# Altium schematic sheet SheetMSP.SchDoc(SheetMSP)
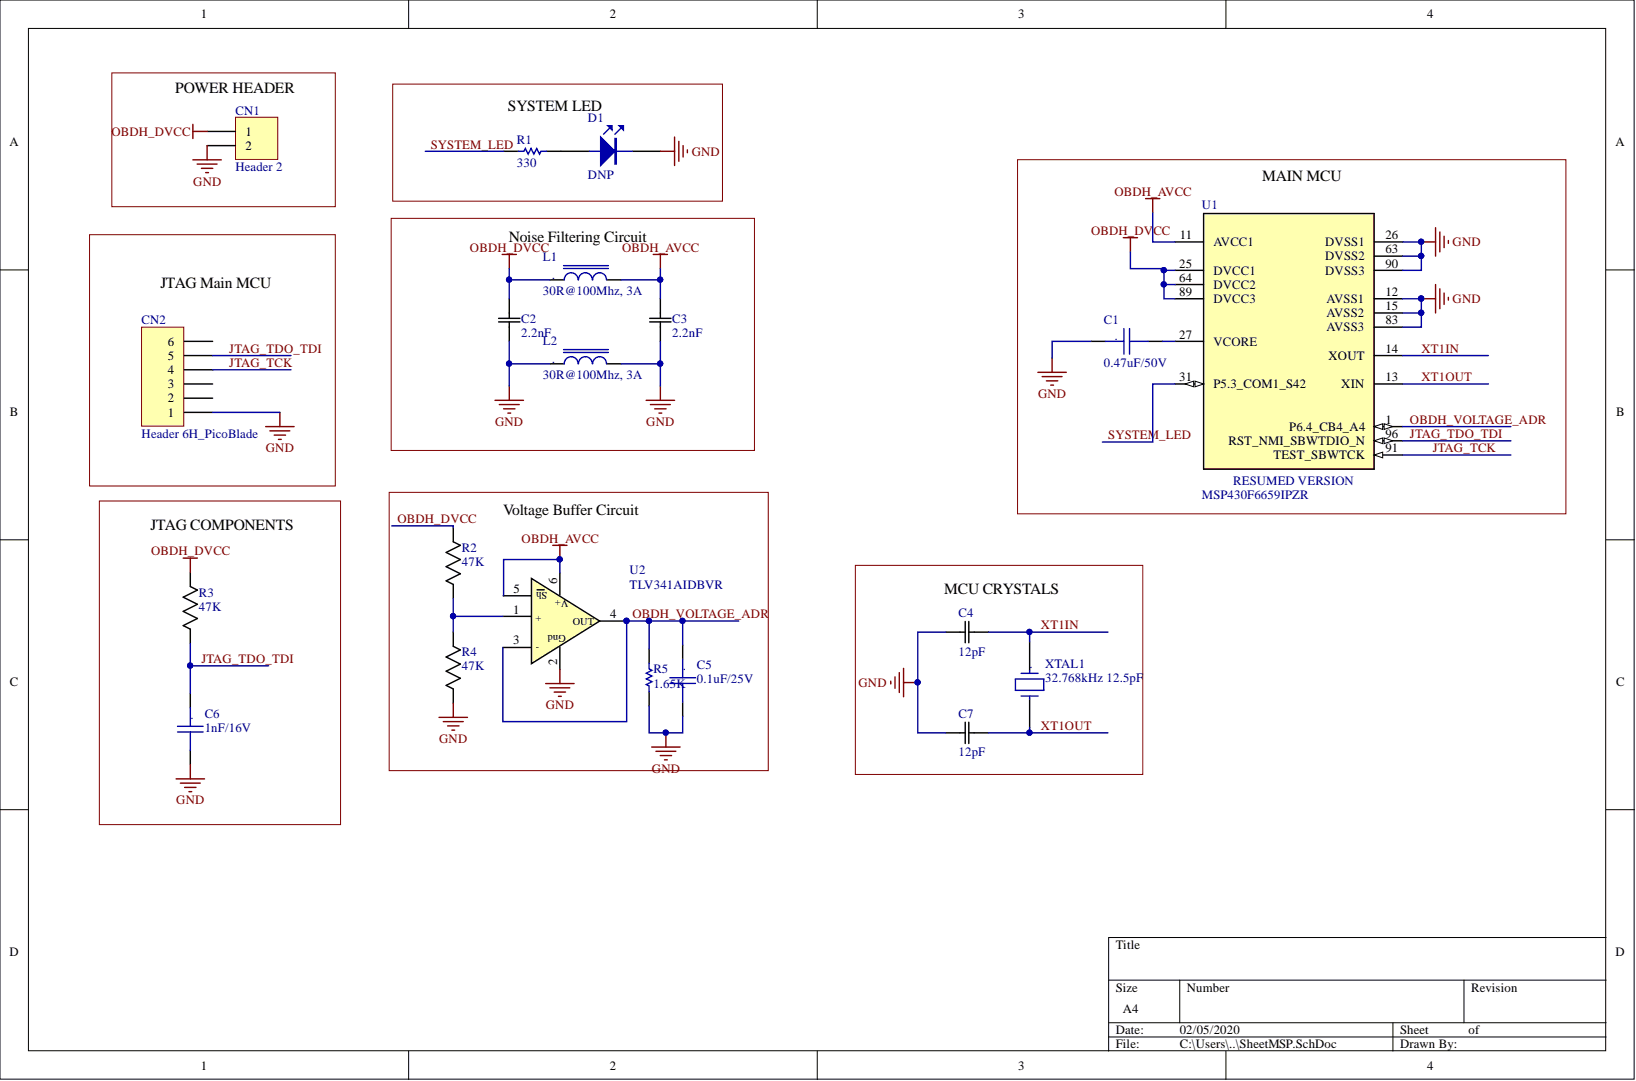
[diagram p0: SheetMSP.SchDoc]

## Parts
C1  0.47uF/50V  [C0805_MD]
C2  2.2nF  [C0402_MD]
C3  2.2nF  [C0402_MD]
C4  12pF  [GRM1555C1H120JA01J]
C5  0.1uF/25V  [C0805_MD]
C6  1nF/16V  [C0603_MD]
C7  12pF  [GRM1555C1H120JA01J]
CN1  Header 2  [HEADER 2M 2.54mm 180 GRAUS]
CN2  Header 6H_PicoBlade  [PICO BLADE 0532610671]
D1  DNP  [L0805 GREEN]
L1  30R@100Mhz, 3A  [L0805]
L2  30R@100Mhz, 3A  [L0805]
R1  330  [R0603_MD]
R2  47K  [R0805_MD]
R3  47K  [R0805_MD]
R4  47K  [R0805_MD]
R5  1.65K  [R0805_MD]
U1  MSP430F6659IPZR  [PZ0100A_N]
U2  TLV341AIDBVR  [SOT-23 6L]
XTAL1  32.768kHz 12.5pF  [ABS06 0805]

## Nets
GND: C1.1 C2.1 C3.1 C4.1 C5.2 C6.2 C7.1 CN1.2 CN2.1 D1.K L2.1 L2.2 R4.2 R5.1 U1.12(AVSS1) U1.15(AVSS2) U1.26(DVSS1) U1.63(DVSS2) U1.83(AVSS3) U1.90(DVSS3) U2.2
OBDH_AVCC: C3.2 L1.2 U1.11(AVCC1) U2.5(Sh) U2.6(V+)
JTAG_TCK: CN2.4 U1.91(TEST_SBWTCK)
JTAG_TDO_TDI: C6.1 CN2.5 R3.2 U1.96(RST_NMI_SBWTDIO_N)
OBDH_DVCC: C2.2 CN1.1 L1.1 R2.1 R3.1 U1.25(DVCC1) U1.64(DVCC2) U1.89(DVCC3)
OBDH_VOLTAGE_ADR: C5.1 R5.2 U1.1(P6.4_CB4_A4) U2.3(-) U2.4(OUT)
SYSTEM_LED: R1.2 U1.31(P5.3_COM1_S42)
XT1IN: C4.2 U1.14(XOUT) XTAL1.1
XT1OUT: C7.2 U1.13(XIN) XTAL1.2
NetC1_2: C1.2 U1.27(VCORE)
NetD1_A: D1.A R1.1
NetR2_2: R2.2 R4.1 U2.1(+)

Not connected: CN2 2 3 6

## Library data of the parts
C1 = Cap_Cer_X7R_0.47uF_50V_0805: CAP CER 0.47UF 50V 10% X7R 0805; Partnumber: C0805C474K5RACTU; NCM: 8532.24.10; Component Kind: Standard; Library Name: MPS430_Task.SchLib
C2 C3 = Cap 2.2nF/16V_0402: Imported; Manufacturer Part Number: GRM155R71C222KA01D; Voltage Rating (DC): 16V; Vendor: Murata; Type: DEV; Tolerance: 10%; Dielectric: X7R; C: Copyright (C) 2015 Accelerated Designs. All rights reserved; Built_By: EMA_UL_Team; Width: 0.02inch; Voltage Rating: 16V; Value: Value; Thickness: 0.022inch; Termination: SMD/SMT; Supplier Part Number 1: 490-6330-1-ND; Supplier 1: Digi-Key; Stock 1: 125973; RefDes: RefDes; Radiation Hardening: No; Pricing 1: 1=0.1, 10=0.03, 100=0.0134, 500=0.0096, 1000=0.0075, 2500=0.0068, 5000=0.0063 (USD); Packaging: Tape and Reel; Package Quantity: 10000; Mount: Surface Mount; Min Operating Temperature: -55°C; Max Operating Temperature: 125°C; Manufacturer_Part_Number: GRM155R71C222KA01D; Manufacturer: Murata; Length: 1mm; Lead Free: Lead Free; Height: 0.5mm; Depth: 0.5mm; ComponentLink6URL: https://datasheet.ciiva.com/6263/partnumbering-e-01-6263630.pdf; ComponentLink6Description: https://datasheet.ciiva.com/6263/partnumbering-e-01-6263630.pdf; ComponentLink5URL: https://datasheet.ciiva.com/11620/product-11620860.pdf; ComponentLink5Description: https://datasheet.ciiva.com/11620/product-11620860.pdf; ComponentLink4URL: https://datasheet.ciiva.com/17453/product-17453067.pdf; ComponentLink4Description: https://datasheet.ciiva.com/17453/product-17453067.pdf; ComponentLink3URL: https://datasheet.ciiva.com/6263/partnumbering-e-01-6263627.pdf; ComponentLink3Description: https://datasheet.ciiva.com/6263/partnumbering-e-01-6263627.pdf; ComponentLink2URL: https://datasheet.ciiva.com/6263/partnumbering-e-01-6263624.pdf; ComponentLink2Description: https://datasheet.ciiva.com/6263/partnumbering-e-01-6263624.pdf; ComponentLink1URL: https://datasheet.ciiva.com/5412/product-5412216.pdf; ComponentLink1Description: https://datasheet.ciiva.com/5412/product-5412216.pdf; Component Kind: Standard; Case Code (Metric): 1005; Case Code (Imperial): 0402; Case/Package: 0402; Capacitance: 2.2nF; Library Name: MPS430_Task.SchLib
C4 C7 = GRM1555C1H120JA01J: Multilayer Ceramic Capacitors MLCC - SMD/SMT 0402 12pF 50volts C0G 5%; Manufacturer: Murata; Voltage Rating (DC): 50V; Width: 0.02inch; Voltage Rating: 50V; Tolerance: 5%; Thickness: 0.022inch; Termination: SMD/SMT; Supplier Part Number 1: GRM1555C1H120JA01J-ND; Supplier 1: Digi-Key; Stock 1: 0; Radiation Hardening: No; Packaging: Tape and Reel; Package Quantity: 50000; Mount: Surface Mount; Min Operating Temperature: -55°C; Max Operating Temperature: 125°C; Manufacturer Part Number: GRM1555C1H120JA01J; Length: 1mm; Height: 0.5mm; Dielectric: C0G; Depth: 0.5mm; ComponentLink1URL: http://psearch.murata.com/capacitor/product/GRM1555C1H120JA01%23.pdf?src-supplier=Avnet; ComponentLink1Description: http://psearch.murata.com/capacitor/product/GRM1555C1H120JA01%23.pdf?src-supplier=Avnet; Component Kind: Standard; Category: Capacitors; Case Code (Metric): 1005; Case Code (Imperial): 0402; Case/Package: 0402; Capacitance: 12pF; Library Name: MPS430_Task.SchLib
C5 = Cap_Cer_X7R_0.1uF_25V_0805: CAP CER 0.1UF 25V 10% X7R 0805; Partnumber: CC0805KRX7R8BB104; NCM: 8532.24.10; Component Kind: Standard; Library Name: MPS430_Task.SchLib
C6 = Cap_Cer_X7R_1nF_16V_0603: CAP CER 1nF 16V 0603 5%; Partnumber: C0603X102J4RECAUTO; NCM: 8532.24.10; Component Kind: Standard; Library Name: MPS430_Task.SchLib
CN1 = Header 2: Header, 2-Pin; PartNumber: 826646-2; LatestRevisionNote: Re-released for DXP Platform.; LatestRevisionDate: 17-Jul-2002; Component Kind: Standard; Publisher: Altium Limited; Library Name: MPS430_Task.SchLib
CN2 = Header 6H_PicoBlade: Header, 6-Pin, Right Angle; Partnumber: 53398-0671; LatestRevisionNote: Re-released for DXP Platform.; LatestRevisionDate: 17-Jul-2002; Component Kind: Standard; Publisher: Altium Limited; Library Name: MPS430_Task.SchLib
D1 = LED/G_0805: SMD Green LED; Partnumber: LTST-C171GKT; NCM: 8541.40.21; Component Kind: Standard; Library Name: MPS430_Task.SchLib
L1 L2 = FB_30R_3A_100MHz_0805: Ferrite Bead 30 OHM 3A 100MHz; Partnumber: BLM21PG300SN1D; NCM: 8504.50.00; Component Kind: Standard; Library Name: MPS430_Task.SchLib
R1 = Resistor 330: Manufacturer Part Number: CRCW0603330RFKEAHP; Manufacturer: Vishay; Voltage Rating (DC): 75V; Tolerance: 1%; Temperature Coefficient: 100ppm/°C; Resistance: 330R; Power Rating: 250mW; Width: 0.033inch; Weight: 7.1E-05oz; Voltage Rating: 75V; Termination: SMD/SMT; Supplier Part Number 1: 541-330SCT-ND; Supplier 1: Digi-Key; Stock 1: 0; REACH SVHC: Unknown; Radiation Hardening: No; Pricing 1: 1=0.18, 10=0.156, 100=0.0609, 1000=0.0255, 2500=0.0234 (USD); Packaging: Tape and Reel; Package Quantity: 1; Number of Terminations: 2; Mount: Surface Mount; Min Operating Temperature: -55°C; Military Standard: Not; Max Power Dissipation: 250mW; Max Operating Temperature: 155°C; Length: 1.6mm; Lead Free: Lead Free; Height: 0.45mm; Depth: 0.85mm; Contact Plating: Tin; Composition: Thick Film; ComponentLink7URL: https://datasheet.ciiva.com/12664/crcwhpe3-46056-12664527.pdf; ComponentLink7Description: https://datasheet.ciiva.com/12664/crcwhpe3-46056-12664527.pdf; ComponentLink6URL: https://datasheet.ciiva.com/16884/crcwhpe3-16884876.pdf; ComponentLink6Description: https://datasheet.ciiva.com/16884/crcwhpe3-16884876.pdf; ComponentLink5URL: https://datasheet.ciiva.com/6551/crcwhpe3-6551644.pdf; ComponentLink5Description: https://datasheet.ciiva.com/6551/crcwhpe3-6551644.pdf; ComponentLink4URL: https://datasheet.ciiva.com/6551/crcwhpe3-6551641.pdf; ComponentLink4Description: https://datasheet.ciiva.com/6551/crcwhpe3-6551641.pdf; ComponentLink3URL: https://datasheet.ciiva.com/6551/crcwhpe3-6551640.pdf; ComponentLink3Description: https://datasheet.ciiva.com/6551/crcwhpe3-6551640.pdf; ComponentLink2URL: https://datasheet.ciiva.com/8944/crcwhpe3-8944983.pdf; ComponentLink2Description: https://datasheet.ciiva.com/8944/crcwhpe3-8944983.pdf; ComponentLink1URL: https://datasheet.ciiva.com/48524/crcwhpe3-48524918.pdf; ComponentLink1Description: https://datasheet.ciiva.com/48524/crcwhpe3-48524918.pdf; Component Kind: Standard; Case Code (Metric): 1608; Case Code (Imperial): 0603; Case/Package: 0603; Library Name: MPS430_Task.SchLib
R2-R4 = Resistor 47 Kohms: Imported; Manufacturer Part Number: ERA-6AEB473V; Manufacturer: Panasonic ECG; Voltage Rating (DC): 100V; Vendor: Panasonic; Tolerance: 0.1%; Temperature Coefficient: 25ppm/°C; Resistance: 47kR; Power Rating: 125mW; C: Copyright (C) 2015 Accelerated Designs. All rights reserved; Built_By: EMA_UL_Team; Width: 1.25mm; Weight: 0.000194oz; Voltage Rating: 100V; Value: Value; Type: DEV; Termination: SMD/SMT; Supplier Part Number 1: P47KDACT-ND; Supplier 1: Digi-Key; Stock 1: 186891; RefDes: RefDes; REACH SVHC: No SVHC; Radiation Hardening: No; Pricing 1: 1=0.36, 10=0.302, 100=0.1182, 1000=0.0495, 2500=0.0454 (USD); Packaging: Tape and Reel; Package Quantity: 1; Number of Terminations: 2; Number of Pins: 2; Mount: Surface Mount; Min Operating Temperature: -55°C; Military Standard: Not; Max Power Dissipation: 125mW; Max Operating Temperature: 155°C; Manufacturer_Part_Number: ERA-6AEB473V; Length: 2mm; Lead Free: Lead Free; Height: 0.5mm; Depth: 1.25mm; Contact Plating: Tin; Composition: Metal Film,Thin Film; ComponentLink12URL: https://datasheet.ciiva.com/26335/453548-da-01-en-widerstand-0805-era-26335717.pdf; ComponentLink12Description: https://datasheet.ciiva.com/26335/453548-da-01-en-widerstand-0805-era-26335717.pdf; ComponentLink11URL: https://datasheet.ciiva.com/48530/era-eng-tds-48530030.pdf; ComponentLink11Description: https://datasheet.ciiva.com/48530/era-eng-tds-48530030.pdf; ComponentLink10URL: https://datasheet.ciiva.com/43455/485442-43455277.pdf; ComponentLink10Description: https://datasheet.ciiva.com/43455/485442-43455277.pdf; ComponentLink9URL: https://datasheet.ciiva.com/17209/aoa0000ce26-17209676.pdf; ComponentLink9Description: https://datasheet.ciiva.com/17209/aoa0000ce26-17209676.pdf; ComponentLink8URL: https://datasheet.ciiva.com/48425/era-eng-tds-48425610.pdf; ComponentLink8Description: https://datasheet.ciiva.com/48425/era-eng-tds-48425610.pdf; ComponentLink7URL: https://datasheet.ciiva.com/16774/70068849-16774537.pdf; ComponentLink7Description: https://datasheet.ciiva.com/16774/70068849-16774537.pdf; ComponentLink6URL: https://datasheet.ciiva.com/7802/485442-7802329.pdf; ComponentLink6Description: https://datasheet.ciiva.com/7802/485442-7802329.pdf; ComponentLink5URL: https://datasheet.ciiva.com/11812/0900766b80beefcc-11812472.pdf; ComponentLink5Description: https://datasheet.ciiva.com/11812/0900766b80beefcc-11812472.pdf; ComponentLink4URL: https://datasheet.ciiva.com/30061/54aoa0000ce26-30061799.pdf; ComponentLink4Description: https://datasheet.ciiva.com/30061/54aoa0000ce26-30061799.pdf; ComponentLink3URL: https://datasheet.ciiva.com/22740/485442-22740326.pdf; ComponentLink3Description: https://datasheet.ciiva.com/22740/485442-22740326.pdf; ComponentLink2URL: https://datasheet.ciiva.com/16759/70068849-16759926.pdf; ComponentLink2Description: https://datasheet.ciiva.com/16759/70068849-16759926.pdf; ComponentLink1URL: https://datasheet.ciiva.com/6138/485442-6138021.pdf; ComponentLink1Description: https://datasheet.ciiva.com/6138/485442-6138021.pdf; Component Kind: Standard; Case Code (Metric): 2012; Case Code (Imperial): 0805; Case/Package: 0805; Library Name: MPS430_Task.SchLib
R5 = R1.65K_1%_1/10W_0805: RES 1.65K OHM 1/10W 0.1% 0805; Partnumber: PCF0805R-1K65BT1; NCM: 8533.21.20; Component Kind: Standard; Library Name: MPS430_Task.SchLib
U1 = MSP430F6659IPZR: Manufacturer: Texas Instruments; Watchdog Timer: Yes; RAM Size: 64KB; Peripherals: Brown-out Detect/Reset,POR,PWM,WDT,DMA,LCD; Oscillator Type: Internal; Number of UART Channels: 3; Number of Timers/Counters: 4; Number of Programmable I/O: 74; Number of A/D Converters: 16; Min Supply Voltage: 1.8V; Memory Type: FLASH; Memory Size: 512KB; Max Supply Voltage: 3.6V; Max Frequency: 20MHz; Interface: SPI,USB,UART,I2C,IrDA,USART,SCI; Data Bus Width: 16b; Core Architecture: RISC; Supplier Part Number 2: 595-MSP430F6659IPZR; Supplier Part Number 1: 296-51438-1-ND; Supplier 2: Mouser; Supplier 1: Digi-Key; Stock 2: 0; Stock 1: 497; Radiation Hardening: No; Pricing 1: 1=11.93, 10=10.968, 25=10.5136, 100=9.2633, 250=8.80864, 500=8.24036 (USD); Packaging: Tape and Reel; Package Quantity: 1000; Number of Pins: 100; Number of I/Os: 74; Mount: Surface Mount; Min Operating Temperature: -40°C; Max Operating Temperature: 85°C; Manufacturer Part Number: MSP430F6659IPZR; Lead Free: Lead Free; Frequency: 20MHz; ComponentLink2URL: http://www.ti.com/lit/ds/symlink/msp430f5659.pdf?src-supplier=Avnet; ComponentLink2Description: http://www.ti.com/lit/ds/symlink/msp430f5659.pdf?src-supplier=Avnet; ComponentLink1URL: http://www.ti.com/lit/ds/symlink/msp430f6659.pdf?src-supplier=Digi-Key; ComponentLink1Description: http://www.ti.com/lit/ds/symlink/msp430f6659.pdf?src-supplier=Digi-Key; Component Kind: Standard; Case/Package: LQFP; Library Name: MPS430_Task.SchLib
XTAL1 = Xtal_32.768kHz_12pF_2_SMD: 32.768kHz ±20ppm Crystal 12.5pF 70 kOhm -40°C ~ 125°C; Partnumber: ECS-.327-12.5-34S-TR; NCM: 8541.60.10; Component Kind: Standard; Library Name: MPS430_Task.SchLib
U2 = TLV341AIDBVR: Partnumber: TLV341AIDBVR; Description: TLV341AIDBVR; Component Kind: Standard; Library Name: MPS430_Task.SchLib
